# Altium schematic sheet 03_Power.SchDoc
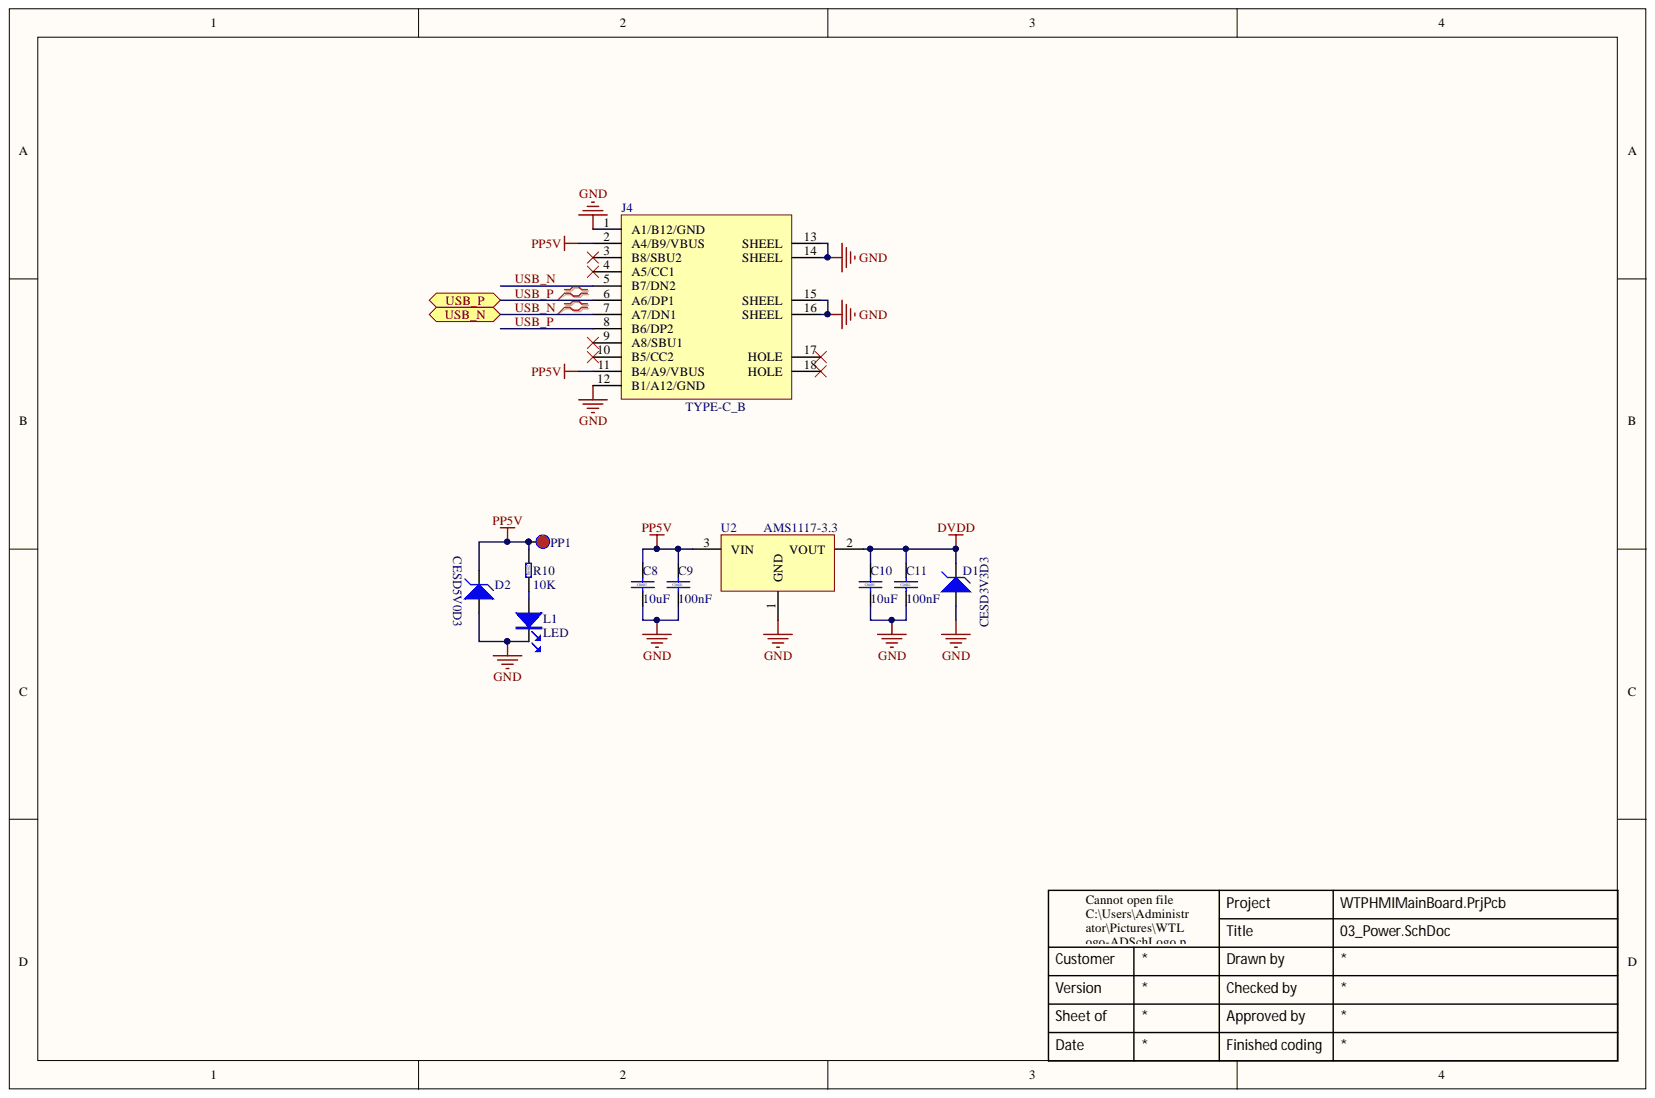
[diagram p0: 03_Power.SchDoc]

## Parts
C8  10uF  [C0603]
C9  100nF  [C0402]
C10  10uF  [C0603]
C11  100nF  [C0402]
D1  CESD3V3D3  [SOD-323]
D2  CESD5V0D3  [SOD-323]
J4  TYPE-C_B  [TYPE-C_B]
L1  LED  [LED0603]
PP1  TestPiont  [TP]  (value not drawn)
R10  10K  [R0402]
U2  AMS1117-3.3  [SOT-223]

## Ports
USB_N, USB_P

## Nets
DVDD: C10.1 C11.1 D1.2 U2.2
GND: C8.2 C9.2 C10.2 C11.2 D1.1 D2.1 J4.1(A1/B12/GND) J4.12(B1/A12/GND) J4.13(SHEEL) J4.14(SHEEL) J4.15(SHEEL) J4.16(SHEEL) L1.2 U2.1
PP5V: C8.1 C9.1 D2.2 J4.2(A4/B9/VBUS) J4.11(B4/A9/VBUS) PP1.1 R10.2 U2.3
USB_N: J4.5(B7/DN2) J4.7(A7/DN1) ; port USB_N
USB_P: J4.6(A6/DP1) J4.8(B6/DP2) ; port USB_P
NetL1_1: L1.1 R10.1

Not connected: J4 3(B8/SBU2) 4(A5/CC1) 9(A8/SBU1) 10(B5/CC2) 17(HOLE) 18(HOLE)

## Library data of the parts
C8 C10 = C0603: Component Kind: Standard; Library Name: Gateway.SchLib
C9 C11 = C0402: Component Kind: Standard; Library Name: Gateway.SchLib
D1 D2 = ESD: Component Kind: Standard; PAK: SOD-323
J4 = TYPE-C_B: Sort: Connector; Component Kind: Standard; Description: TYPE-C_B; PackageDescription: TYPE-C接口，母座; PackageReference: TYPE-C_B
R10 = R0402: Component Kind: Standard; Library Name: Gateway.SchLib
U2 = AMS1117-3.3: IC,Power,AMS1117-3.3,5V转3.3V,SOT223; Component Kind: Standard; Library Name: Gateway.SchLib; PAK: SOT-223
L1 = LED: Component Kind: Standard; PAK: LED0603
PP1 = TestPiont: Component Kind: Standard
